# Altium schematic sheet Sheet2.SchDoc(Sheet2)
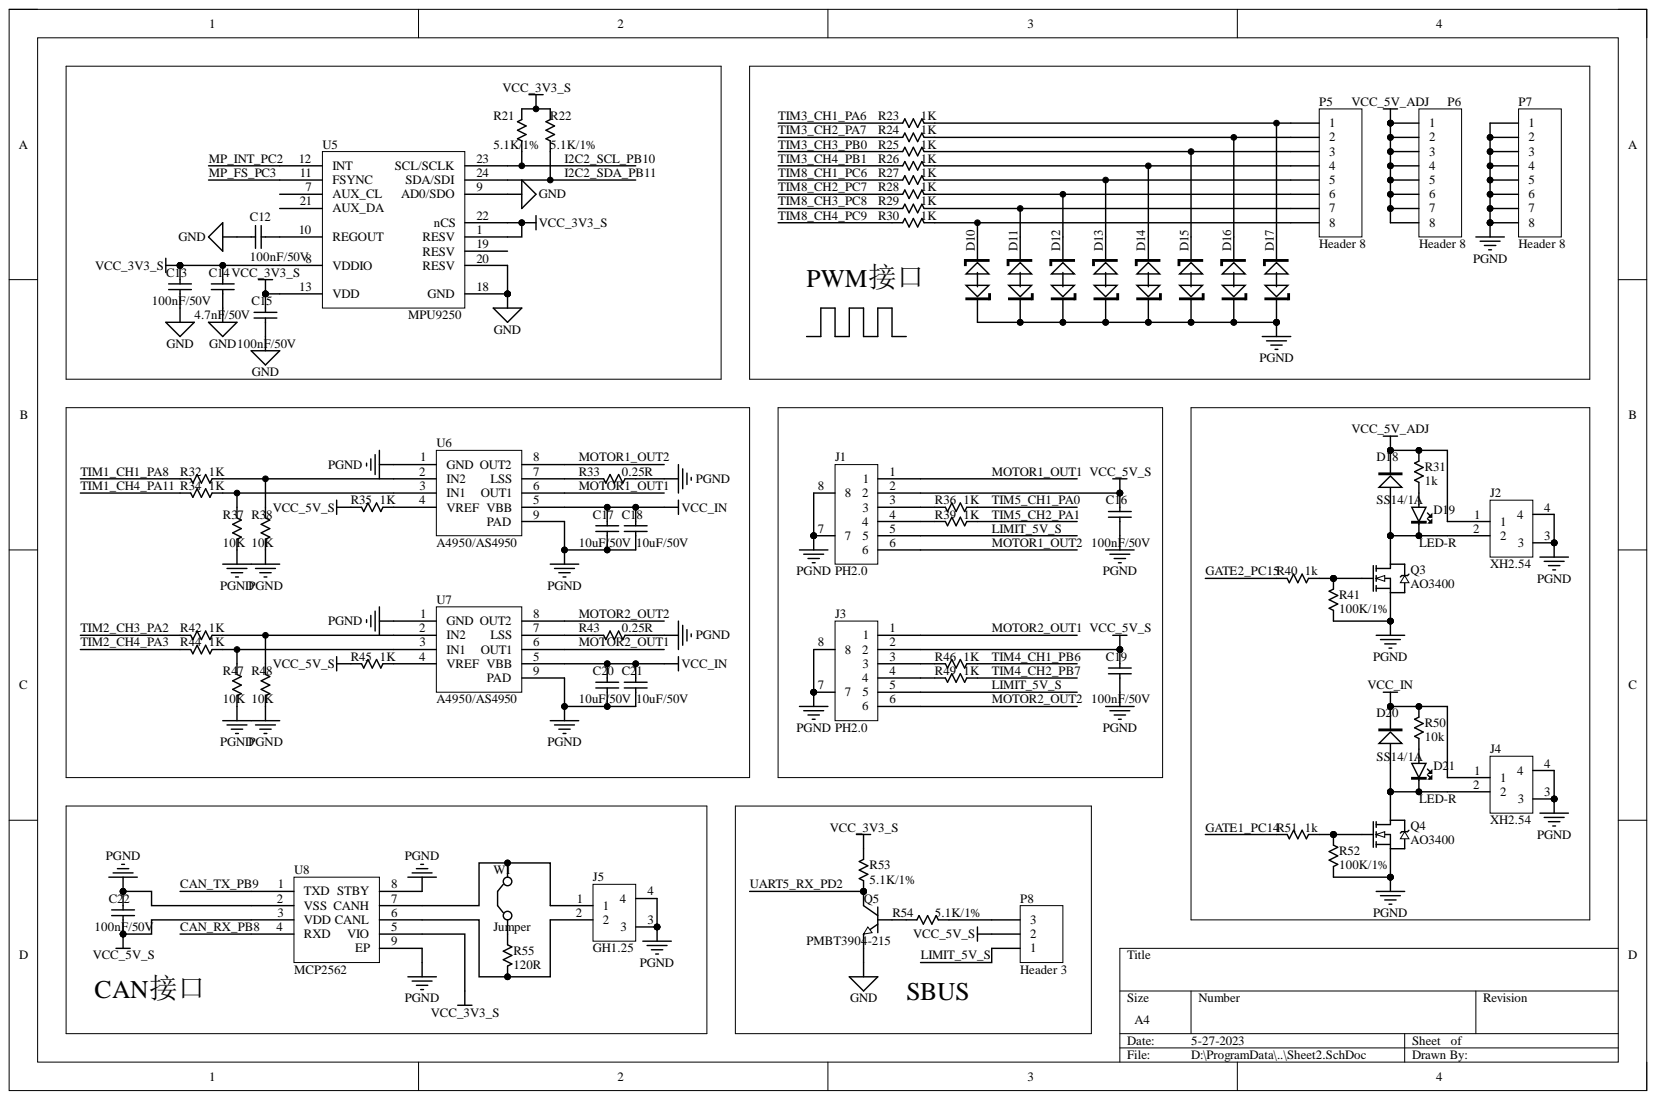
[diagram p0: Sheet2.SchDoc]

## Parts
C12  100nF/50V  [C 0402]
C13  100nF/50V  [C 0402]
C14  4.7nF/50V  [C 0402]
C15  100nF/50V  [C 0402]
C16  100nF/50V  [C 0402]
C17  10uF/50V  [C 0805]
C18  10uF/50V  [C 0805]
C19  100nF/50V  [C 0402]
C20  10uF/50V  [C 0805]
C21  10uF/50V  [C 0805]
C22  100nF/50V  [C 0402]
D10  PESD5V0V1BL  [SOD882]  (value not drawn)
D11  PESD5V0V1BL  [SOD882]  (value not drawn)
D12  PESD5V0V1BL  [SOD882]  (value not drawn)
D13  PESD5V0V1BL  [SOD882]  (value not drawn)
D14  PESD5V0V1BL  [SOD882]  (value not drawn)
D15  PESD5V0V1BL  [SOD882]  (value not drawn)
D16  PESD5V0V1BL  [SOD882]  (value not drawn)
D17  PESD5V0V1BL  [SOD882]  (value not drawn)
D18  SS14/1A  [SOD-323]
D19  LED-R  [LED 0603R]
D20  SS14/1A  [SOD-323]
D21  LED-R  [LED 0603R]
J1  PH2.0  [PH2.0 S-L-6P]
J2  XH2.54  [XH2.54-LS-2P]
J3  PH2.0  [PH2.0 S-L-6P]
J4  XH2.54  [XH2.54-LS-2P]
J5  GH1.25  [JST-BM02B-GHS-TBT]
P5  Header 8  [HDR-1X8]
P6  Header 8  [HDR-1X8]
P7  Header 8  [HDR-1X8]
P8  Header 3  [HDR-1X3_H]
Q3  AO3400  [SOT23-3N-AO3400]
Q4  AO3400  [SOT23-3N-AO3400]
Q5  PMBT3904-215  [SOT23-3N]
R21  5.1K/1%  [R 0402]
R22  5.1K/1%  [R 0402]
R23  1K  [R 0402]
R24  1K  [R 0402]
R25  1K  [R 0402]
R26  1K  [R 0402]
R27  1K  [R 0402]
R28  1K  [R 0402]
R29  1K  [R 0402]
R30  1K  [R 0402]
R31  1k  [R 0402]
R32  1K  [R 0402]
R33  0.25R  [R 1206]
R34  1K  [R 0402]
R35  1K  [R 0402]
R36  1K  [R 0402]
R37  10K  [R 0402]
R38  10K  [R 0402]
R39  1K  [R 0402]
R40  1k  [R 0402]
R41  100K/1%  [R 0402]
R42  1K  [R 0402]
R43  0.25R  [R 1206]
R44  1K  [R 0402]
R45  1K  [R 0402]
R46  1K  [R 0402]
R47  10K  [R 0402]
R48  10K  [R 0402]
R49  1K  [R 0402]
R50  10k  [R 0402]
R51  1k  [R 0402]
R52  100K/1%  [R 0402]
R53  5.1K/1%  [R 0402]
R54  5.1K/1%  [R 0402]
R55  120R  [R 0402]
U5  MPU9250  [MPU9250]
U6  A4950/AS4950  [A4950]
U7  A4950/AS4950  [A4950]
U8  MCP2562  [MCP2562]
W1  Jumper  [HDR-1x2_2.0MM]

## Nets
GND: C12.2 C13.2 C14.1 C15.1 Q5.2 U5.9(AD0/SDO) U5.18(GND) U5.20(RESV)
PGND: C16.1 C17.1 C18.1 C19.1 C20.1 C21.1 C22.2 D10.2 D11.2 D12.2 D13.2 D14.2 D15.2 D16.2 D17.2 J1.7 J1.8 J2.3 J2.4 J3.7 J3.8 J4.3 J4.4 J5.3 J5.4 P7.1 P7.2 P7.3 P7.4 P7.5 P7.6 P7.7 P7.8 Q3.3 Q4.3 R33.2 R37.1 R38.1 R41.1 R43.2 R47.1 R48.1 R52.2 U6.1(GND) U6.9(PAD) U7.1(GND) U7.9(PAD) U8.2(VSS) U8.8(STBY) U8.9(EP)
VCC_3V3_S: C13.1 C14.2 C15.2 R21.1 R22.1 R53.1 U5.1(RESV) U5.8(VDDIO) U5.13(VDD) U5.22(nCS) U8.5(VIO)
VCC_5V_ADJ: D18.2 J2.1 P6.1 P6.2 P6.3 P6.4 P6.5 P6.6 P6.7 P6.8 R31.1
VCC_5V_S: C16.2 C19.2 C22.1 J1.2 J3.2 P8.2 R35.1 R45.1 U8.3(VDD)
VCC_IN: C17.2 C18.2 C20.2 C21.2 D20.2 J4.1 R50.1 U6.5(VBB) U7.5(VBB)
CAN_RX_PB8: U8.4(RXD)
CAN_TX_PB9: U8.1(TXD)
GATE1_PC14: R51.1
GATE2_PC15: R40.1
I2C2_SCL_PB10: R21.2 U5.23(SCL/SCLK)
I2C2_SDA_PB11: R22.2 U5.24(SDA/SDI)
LIMIT_5V_S: J1.5 J3.5 P8.1
MOTOR1_OUT1: J1.1 U6.6(OUT1)
MOTOR1_OUT2: J1.6 U6.8(OUT2)
MOTOR2_OUT1: J3.1 U7.6(OUT1)
MOTOR2_OUT2: J3.6 U7.8(OUT2)
MP_FS_PC3: U5.11(FSYNC)
MP_INT_PC2: U5.12(INT)
TIM1_CH1_PA8: R32.1
TIM1_CH4_PA11: R34.1
TIM2_CH3_PA2: R42.1
TIM2_CH4_PA3: R44.1
TIM3_CH1_PA6: R23.2
TIM3_CH2_PA7: R24.2
TIM3_CH3_PB0: R25.2
TIM3_CH4_PB1: R26.2
TIM4_CH1_PB6: R46.2
TIM4_CH2_PB7: R49.2
TIM5_CH1_PA0: R36.2
TIM5_CH2_PA1: R39.2
TIM8_CH1_PC6: R27.2
TIM8_CH2_PC7: R28.2
TIM8_CH3_PC8: R29.2
TIM8_CH4_PC9: R30.2
UART5_RX_PD2: Q5.3 R53.2
NetC12_1: C12.1 U5.10(REGOUT)
NetD10_1: D10.1 P5.8 R30.1
NetD11_1: D11.1 P5.7 R29.1
NetD12_1: D12.1 P5.6 R28.1
NetD13_1: D13.1 P5.5 R27.1
NetD14_1: D14.1 P5.4 R26.1
NetD15_1: D15.1 P5.3 R25.1
NetD16_1: D16.1 P5.2 R24.1
NetD17_1: D17.1 P5.1 R23.1
NetD18_1: D18.1 D19.2 J2.2 Q3.2
NetD19_1: D19.1 R31.2
NetD20_1: D20.1 D21.2 J4.2 Q4.2
NetD21_1: D21.1 R50.2
NetJ1_3: J1.3 R36.1
NetJ1_4: J1.4 R39.1
NetJ3_3: J3.3 R46.1
NetJ3_4: J3.4 R49.1
NetJ5_1: J5.1 U8.7(CANH) W1.2
NetJ5_2: J5.2 R55.1 U8.6(CANL)
NetP8_3: P8.3 R54.1
NetQ3_1: Q3.1 R40.2 R41.2
NetQ4_1: Q4.1 R51.2 R52.1
NetQ5_1: Q5.1 R54.2
NetR32_2: R32.2 R38.2 U6.2(IN2)
NetR33_1: R33.1 U6.7(LSS)
NetR34_2: R34.2 R37.2 U6.3(IN1)
NetR35_2: R35.2 U6.4(VREF)
NetR42_2: R42.2 R48.2 U7.2(IN2)
NetR43_1: R43.1 U7.7(LSS)
NetR44_2: R44.2 R47.2 U7.3(IN1)
NetR45_2: R45.2 U7.4(VREF)
NetR55_2: R55.2 W1.1

Not connected: U5 7(AUX_CL) 19(RESV) 21(AUX_DA)

## Library data of the parts
C12-C22 = CAP: Capacitor; Component Kind: Standard; Library Name: Passive Components Class.SchLib
D18 D20 = 1N4007: 整流二极管; 版权归属: 黎明电子style; BOM名称: 整流二极管; Component Kind: Standard; Library Name: 二极管-整流桥.SchLib; 学习资源: https://lmdzstyle.taobao.com/
D19 D21 = LED: Light Emitting Diode; Component Kind: Standard; Library Name: Passive Components Class.SchLib
J1 J3 = GH1.25_6P: Component Kind: Standard; Description: GH1.25_6P; Library Name: 接口类.SchLib
J2 J4 J5 = PH2.0-2P: 立式贴片; Component Kind: Standard; Library Name: 接口类.SchLib
P5-P7 = Header 8: Header, 8-Pin; Component Kind: Standard; LatestRevisionDate: 17-Jul-2002; LatestRevisionNote: Re-released for DXP Platform.; Library Name: Miscellaneous Connectors.IntLib; Signal Integrity: Connector; Publisher: Altium Limited
P8 = Header 3: Header, 3-Pin; Component Kind: Standard; LatestRevisionDate: 17-Jul-2002; LatestRevisionNote: Re-released for DXP Platform.; Library Name: Miscellaneous Connectors.IntLib; Signal Integrity: Connector; Publisher: Altium Limited
Q3 Q4 = NMOS-2: N-Channel Power MOSFET; Note: Pin 2 is electrically connected to pin 4.; Component Kind: Standard; ComponentLink1Description: Manufacturer Link; ComponentLink1URL: http://www.irf.com/; DatasheetVersion: PD-9.814; LatestRevisionDate: 17-Jul-2002; LatestRevisionNote: Re-released for DXP Platform.; Library Name: Miscellaneous Devices.IntLib; PackageDescription: TO, Thru-Hole, Vertical, Heatsink Mounted; 3 In-Line Leads; Pitch 2.54 mm; PackageReference: TO-220AB; PackageVersion: Feb-1997; Published: 8-Jun-2000; Simulation: IRF1010; Publisher: Altium Limited
Q5 = 8050-SMD: 高频放大-NPN型; 属性: 40V 1.5A 1W 100MHZ; 版权归属: 黎明电子style; BOM名称: 高频放大-NPN型; Component Kind: Standard; Library Name: 三极管.SchLib; 学习资源: https://lmdzstyle.taobao.com/
R21-R55 = RES: Resistor; Component Kind: Standard; Library Name: Passive Components Class.SchLib
U6 U7 = A4950: Component Kind: Standard; Description: A4950; Library Name: IC芯片.SchLib
W1 = Jumper: Jumper Wire; Component Kind: Standard; LatestRevisionDate: 17-Jul-2002; LatestRevisionNote: Re-released for DXP Platform.; Library Name: Miscellaneous Devices.IntLib; PackageDescription: Radial Cap, Thru-Hole; 2 Leads; 0.2 in Pin Spacing; PackageReference: RAD-0.2; Published: 24-Mar-1999; Publisher: Altium Limited
D10-D17 = PESD5V0V1BL: Component Kind: Standard; Description: PESD5V0V1BL; Library Name: 二极管-整流桥.SchLib
U5 = MPU9250: Component Kind: Standard; Library Name: Schlib1.SchLib
U8 = MCP2562: Component Kind: Standard; Description: MCP2562; Library Name: IC芯片.SchLib
